# Altium schematic sheet DC-DC.SchDoc
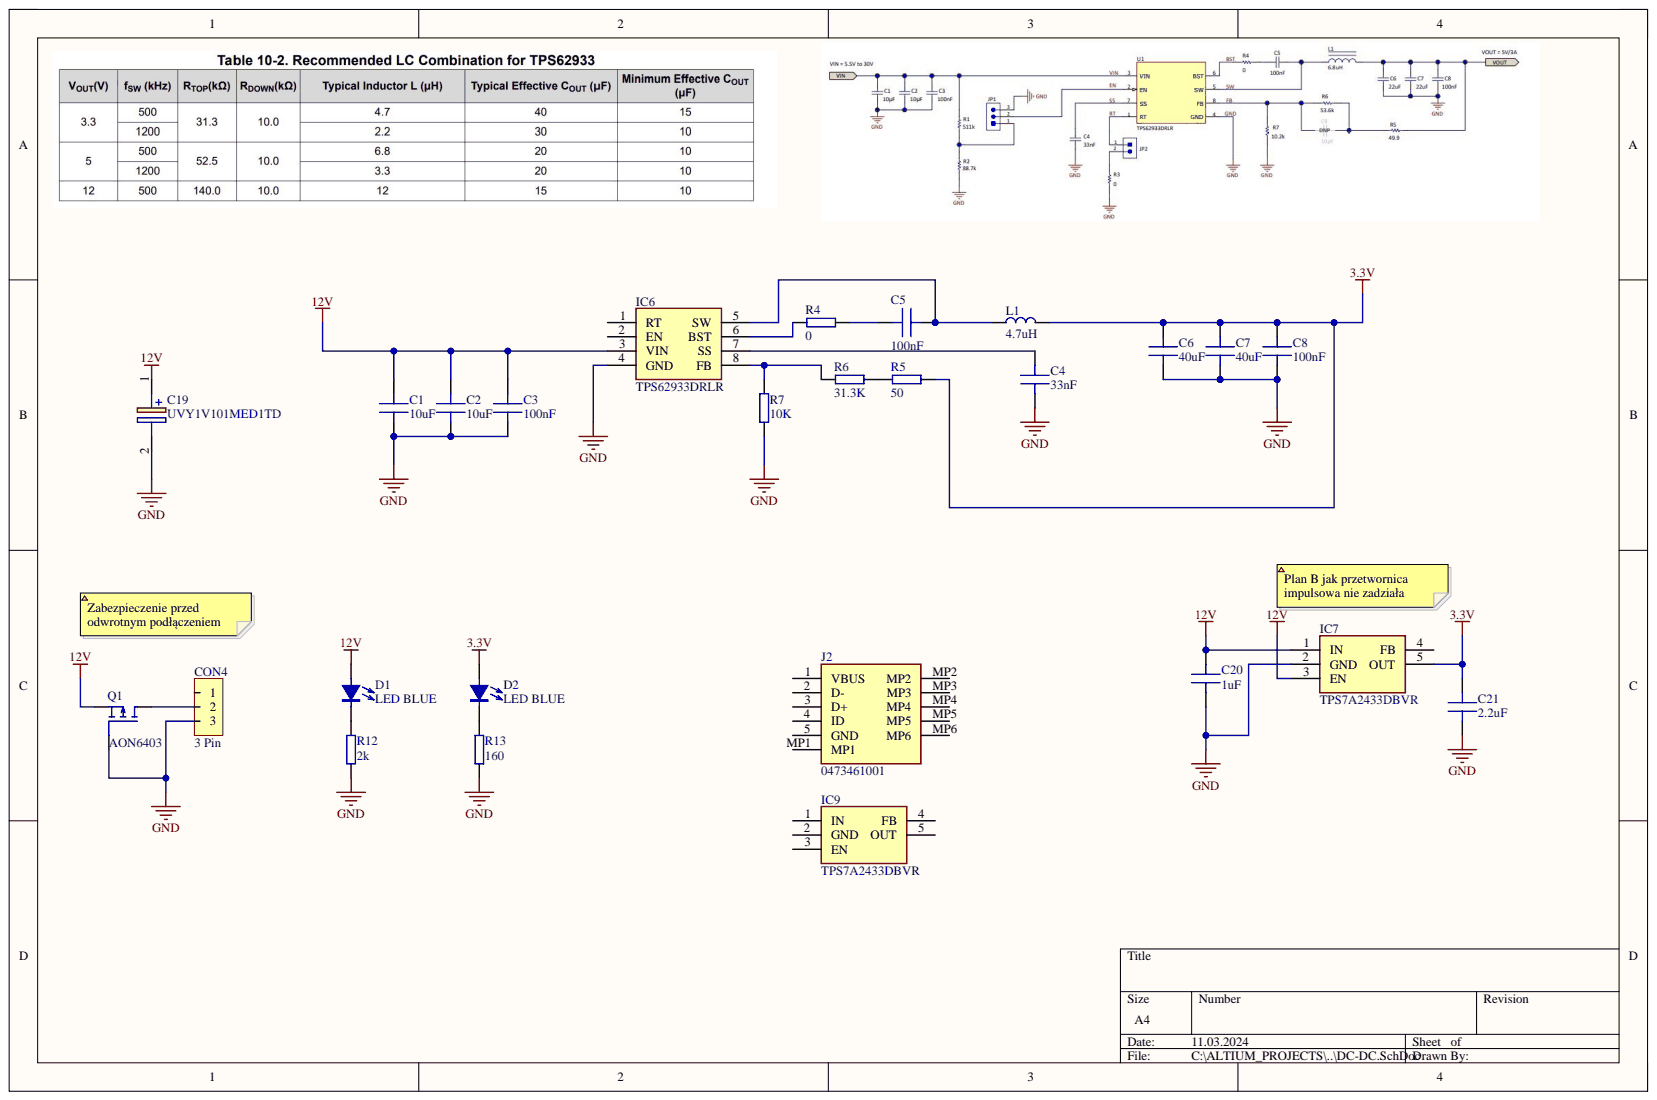
[diagram p0: DC-DC.SchDoc]

## Parts
C1  10uF  [C 0603]
C2  10uF  [C 0603]
C3  100nF  [C 0603]
C4  33nF  [C 0603]
C5  100nF  [C 0603]
C6  40uF  [C 0603]
C7  40uF  [C 0603]
C8  100nF  [C 0603]
C19  UVY1V101MED1TD  [CAPPRD250W50D655H1250]
C20  1uF  [C 0603]
C21  2.2uF  [C 0603]
CON4  3 Pin  [BM03B-SRSS-TB]
D1  LED BLUE  [LED 0603 BLUE]
D2  LED BLUE  [LED 0603 BLUE]
IC6  TPS62933DRLR  [SOTFL50P160X60-8N]
IC7  TPS7A2433DBVR  [SOT95P280X145-5N]
IC9  TPS7A2433DBVR  [SOT95P280X145-5N]
J2  0473461001  [0473461001]
L1  4.7uH  [HPI0630]
Q1  AON6403  [DFN 5x6]
R4  0  [R 0402]
R5  50  [R 0402]
R6  31.3K  [R 0402]
R7  10K  [R 0402]
R12  2k  [R 0402]
R13  160  [R 0402]

## Nets
3.3V: C6.1 C7.1 C8.1 C21.1 D2.1 IC7.5(OUT) L1.2 R5.1
12V: C1.1 C2.1 C3.1 C19.1 C20.1 D1.1 IC6.3(VIN) IC7.1(IN) IC7.3(EN) Q1.1, 2, 3
GND: C1.2 C2.2 C3.2 C4.2 C6.2 C7.2 C8.2 C19.2 C20.2 C21.2 CON4.3 IC6.4(GND) IC7.2(GND) Q1.4 R7.1 R12.1 R13.1
NetC4_1: C4.1 IC6.7(SS)
NetC5_1: C5.1 R4.1
NetC5_2: C5.2 IC6.5(SW) L1.1
NetCON4_2: CON4.2 Q1.5_8
NetD1_2: D1.2 R12.2
NetD2_2: D2.2 R13.2
NetIC6_6: IC6.6(BST) R4.2
NetIC6_8: IC6.8(FB) R6.2 R7.2
NetR5_2: R5.2 R6.1

Not connected: CON4 1; IC6 1(RT) 2(EN); IC7 4(FB); IC9 1(IN) 2(GND) 3(EN) 4(FB) 5(OUT); J2 1(VBUS) 2(D-) 3(D+) 4(ID) 5(GND) MP1 MP2 MP3 MP4 MP5 MP6

## Library data of the parts
C1-C8 C20 C21 = Capacitor: MLCC Capacitor; Component Kind: Standard; Library Name: Components.IntLib
C19 = UVY1V101MED1TD: Capacitor Polarised; Manufacturer_Name: Nichicon; Arrow Part Number: UVY1V101MED1TD; Arrow Price/Stock: https://www.arrow.com/en/products/uvy1v101med1td/nichicon; Component Kind: Standard; Datasheet Link: http://nichicon-us.com/english/products/pdfs/e-uvy.pdf; Height: 12.5mm; Manufacturer_Part_Number: UVY1V101MED1TD
IC6 = TPS62933DRLR: Integrated Circuit; Manufacturer_Name: Texas Instruments; Arrow Part Number: TPS62933DRLR; Arrow Price/Stock: https://www.arrow.com/en/products/tps62933drlr/texas-instruments?utm_currency=USD&region=nac; Component Kind: Standard; Datasheet Link: https://www.ti.com/lit/ds/symlink/tps62933.pdf?ts=1626073394234; Height: 0.6mm; Manufacturer_Part_Number: TPS62933DRLR; Mouser Part Number: 595-TPS62933DRLR; Mouser Price/Stock: https://www.mouser.co.uk/ProductDetail/Texas-Instruments/TPS62933DRLR?qs=Rp5uXu7WBW9fv47wfQVntQ%3D%3D
IC7 IC9 = TPS7A2433DBVR: Integrated Circuit; Manufacturer_Name: Texas Instruments; Arrow Part Number: TPS7A2433DBVR; Arrow Price/Stock: null?region=nac; Component Kind: Standard; Datasheet Link: https://www.ti.com/lit/gpn/TPS7A24; Height: 1.45mm; Manufacturer_Part_Number: TPS7A2433DBVR; Mouser Part Number: 595-TPS7A2433DBVR; Mouser Price/Stock: https://www.mouser.co.uk/ProductDetail/Texas-Instruments/TPS7A2433DBVR?qs=wnTfsH77Xs7ROpwa%2Fm0jWA%3D%3D
J2 = 0473461001: Connector; Manufacturer_Name: Molex; Arrow Part Number: 0473461001; Arrow Price/Stock: https://www.arrow.com/en/products/0473461001/molex?region=nac; Component Kind: Standard; Datasheet Link: https://componentsearchengine.com/Datasheets/1/0473461001.pdf; Height: 2.45mm; Manufacturer_Part_Number: 0473461001; Mouser Part Number: N/A; Mouser Price/Stock: https://www.mouser.co.uk/ProductDetail/Molex/0473461001?qs=2bnkQPT%252BPafTZgGVt%2FOGfA%3D%3D
L1 = Inductor: General pourpouse inductor; Component Kind: Standard; Library Name: Components.IntLib
Q1 = AON6403: P-Mosfet 30V 67A Rds(on) 3.1mR; Component Kind: Standard; Library Name: Components.IntLib
R4-R7 R12 R13 = Resistor: General pourpouse resistor; Component Kind: Standard; Library Name: Components.IntLib
CON4 = 3 Pin: Component Kind: Standard; Library Name: Connectors.IntLib
D1 D2 = LED BLUE: Component Kind: Standard; Library Name: Components.IntLib
